# Altium schematic sheet CAN_RS232.SchDoc
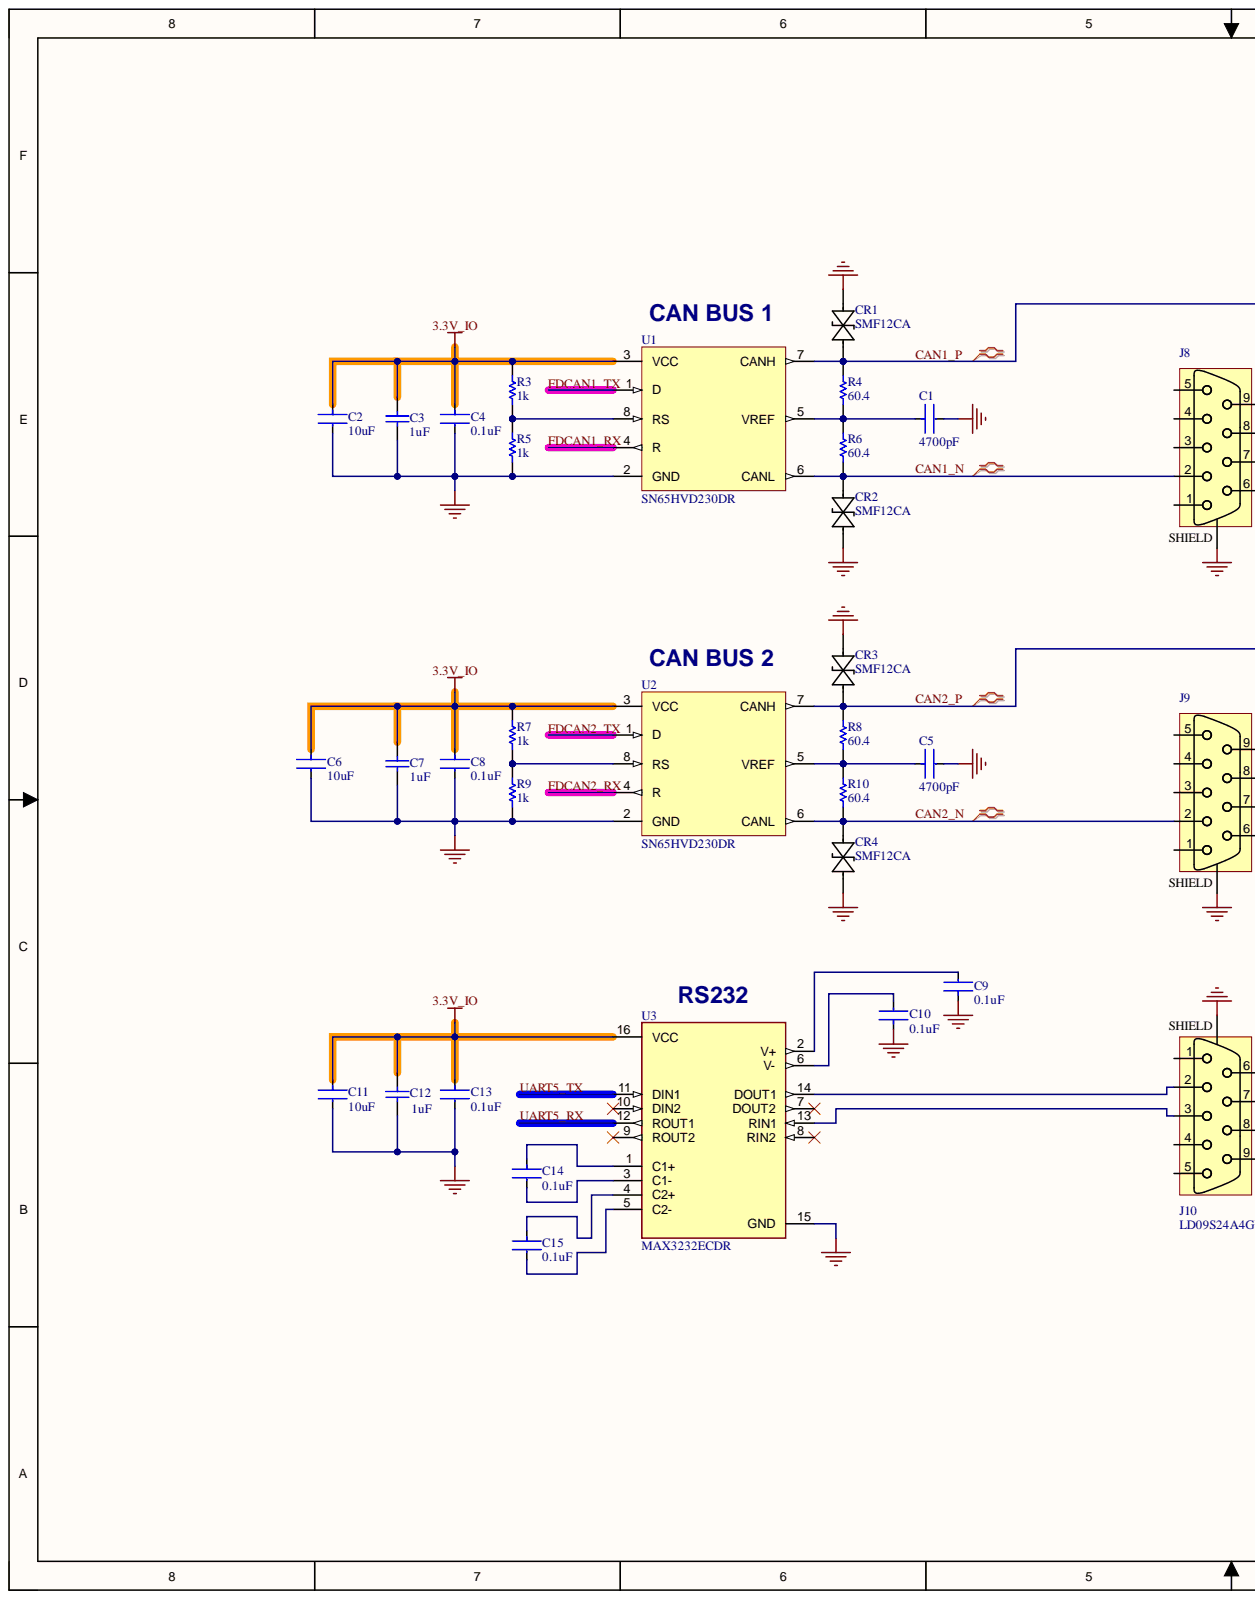
[diagram p0: CAN_RS232.SchDoc, left]
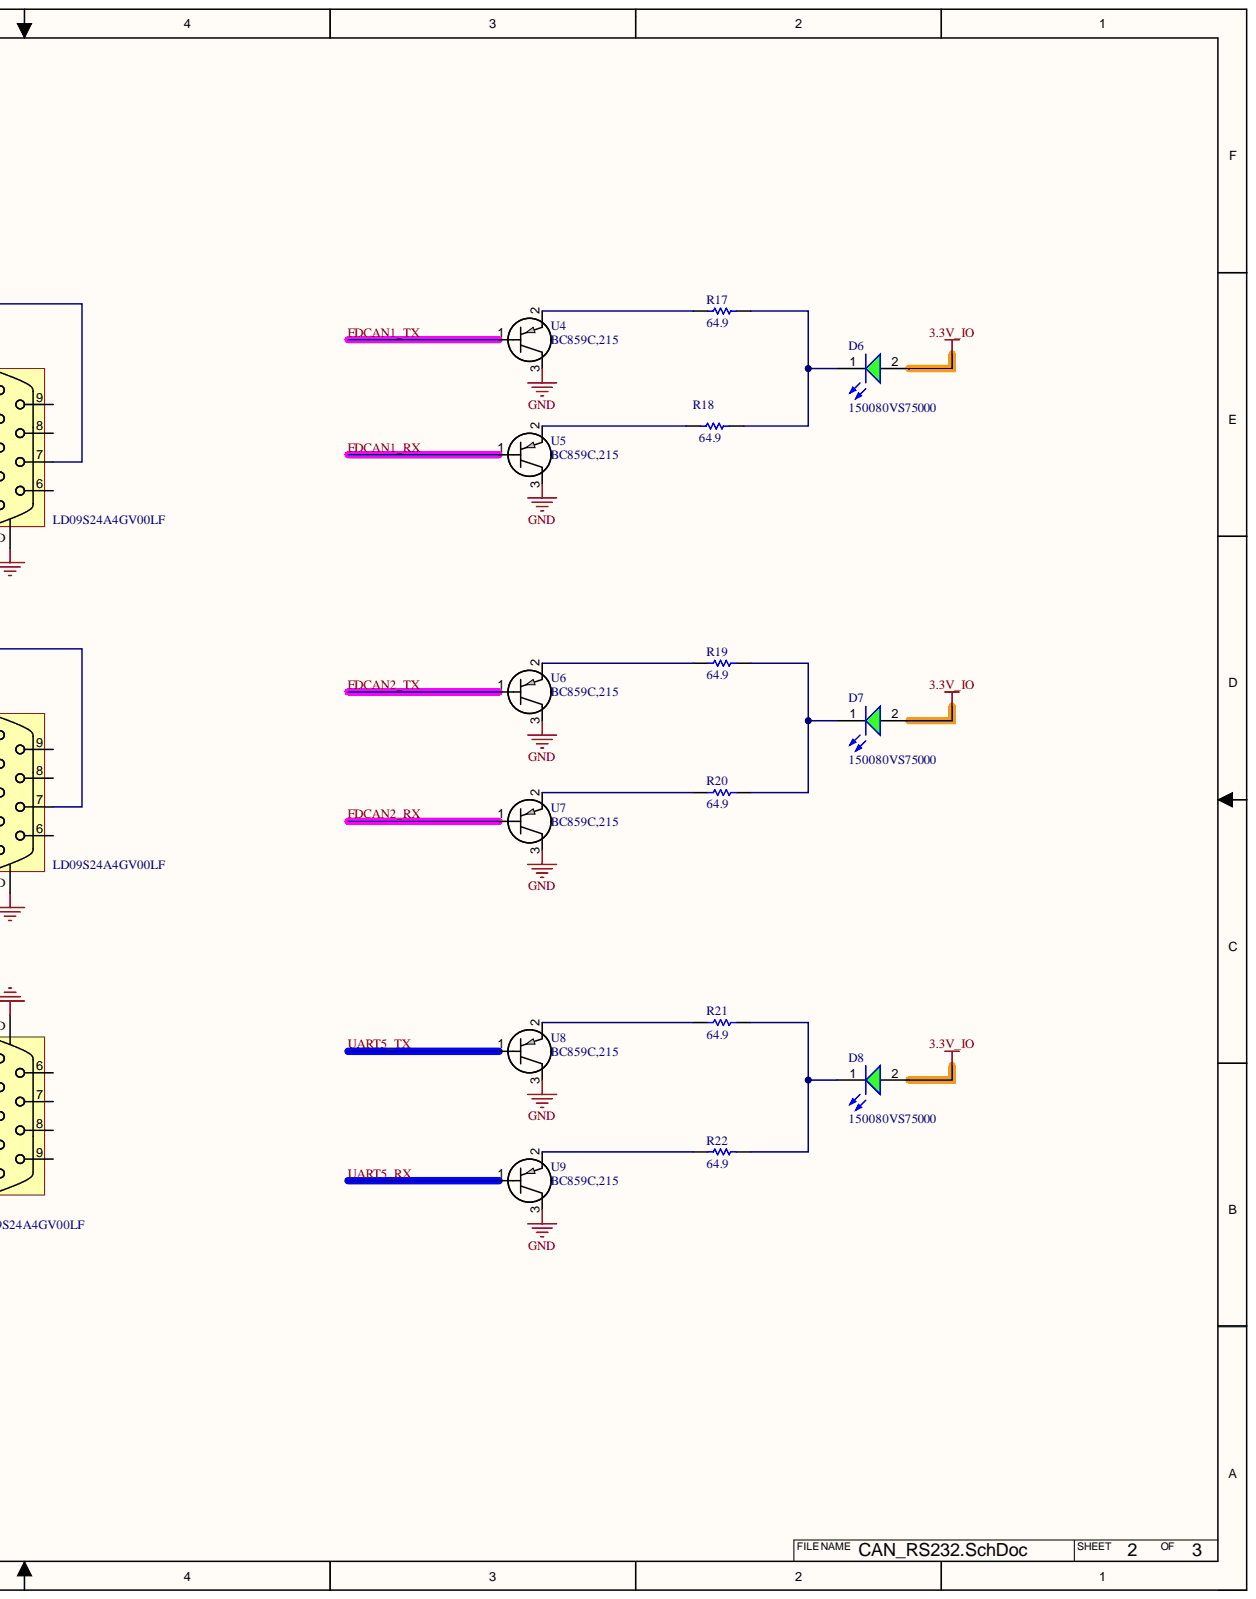
[diagram p1: CAN_RS232.SchDoc, right]

## Parts
C1  4700pF  [FP-CC0805-0_85-MFG]
C2  10uF  [FP-CL31-IPC_B]
C3  1uF  [CAPC2013X100X50ML20T25]
C4  0.1uF  [FP-CC0805-0_85-MFG]
C5  4700pF  [FP-CC0805-0_85-MFG]
C6  10uF  [FP-CL31-IPC_B]
C7  1uF  [CAPC2013X100X50ML20T25]
C8  0.1uF  [FP-CC0805-0_85-MFG]
C9  0.1uF  [FP-CC0805-0_85-MFG]
C10  0.1uF  [FP-CC0805-0_85-MFG]
C11  10uF  [FP-CL31-IPC_B]
C12  1uF  [CAPC2013X100X50ML20T25]
C13  0.1uF  [FP-CC0805-0_85-MFG]
C14  0.1uF  [FP-CC0805-0_85-MFG]
C15  0.1uF  [FP-CC0805-0_85-MFG]
CR1  SMF12CA  [SOD-123F_LTF]
CR2  SMF12CA  [SOD-123F_LTF]
CR3  SMF12CA  [SOD-123F_LTF]
CR4  SMF12CA  [SOD-123F_LTF]
D6  150080VS75000  [WL-SMCW_0805_150080xx75000]
D7  150080VS75000  [WL-SMCW_0805_150080xx75000]
D8  150080VS75000  [WL-SMCW_0805_150080xx75000]
J8  LD09S24A4GV00LF  [ASSMANN_A-DF09A/KG-T2S]
J9  LD09S24A4GV00LF  [ASSMANN_A-DF09A/KG-T2S]
J10  LD09S24A4GV00LF  [ASSMANN_A-DF09A/KG-T2S]
R3  1k  [RESC2013X60X35ML10T20]
R4  60.4  [RESC2013X60X35LL10T20]
R5  1k  [RESC2013X60X35ML10T20]
R6  60.4  [RESC2013X60X35LL10T20]
R7  1k  [RESC2013X60X35ML10T20]
R8  60.4  [RESC2013X60X35LL10T20]
R9  1k  [RESC2013X60X35ML10T20]
R10  60.4  [RESC2013X60X35LL10T20]
R17  64.9  [RESC2013X60X35LL10T20]
R18  64.9  [RESC2013X60X35LL10T20]
R19  64.9  [RESC2013X60X35LL10T20]
R20  64.9  [RESC2013X60X35LL10T20]
R21  64.9  [RESC2013X60X35LL10T20]
R22  64.9  [RESC2013X60X35LL10T20]
U1  SN65HVD230DR  [SOIC127P599X175-8N]
U2  SN65HVD230DR  [SOIC127P599X175-8N]
U3  MAX3232ECDR  [SOIC127P600X175-16N]
U4  BC859C,215  [SOT23_NEX]
U5  BC859C,215  [SOT23_NEX]
U6  BC859C,215  [SOT23_NEX]
U7  BC859C,215  [SOT23_NEX]
U8  BC859C,215  [SOT23_NEX]
U9  BC859C,215  [SOT23_NEX]

## Nets
3.3V_IO: C2.1 C3.2 C4.1 C6.1 C7.2 C8.1 C11.1 C12.2 C13.1 D6.2 D7.2 D8.2 R3.2 R7.2 U1.3(VCC) U2.3(VCC) U3.16(VCC)
GND: C1.2 C2.2 C3.1 C4.2 C5.2 C6.2 C7.1 C8.2 C9.1 C10.1 C11.2 C12.1 C13.2 CR1.1 CR2.1 CR3.2 CR4.2 J8.SHIELD J9.SHIELD J10.SHIELD R5.1 R9.1 U1.2(GND) U2.2(GND) U3.15(GND) U4.3 U5.3 U6.3 U7.3 U8.3 U9.3
CAN1_N: CR2.2 J8.2 R6.1 U1.6(CANL)
CAN1_P: CR1.2 J8.7 R4.2 U1.7(CANH)
CAN2_N: CR4.1 J9.2 R10.1 U2.6(CANL)
CAN2_P: CR3.1 J9.7 R8.2 U2.7(CANH)
FDCAN1_RX: U1.4(R) U5.1
FDCAN1_TX: U1.1(D) U4.1
FDCAN2_RX: U2.4(R) U7.1
FDCAN2_TX: U2.1(D) U6.1
UART5_RX: U3.12(ROUT1) U9.1
UART5_TX: U3.11(DIN1) U8.1
NetC1_1: C1.1 R4.1 R6.2 U1.5(VREF)
NetC5_1: C5.1 R8.1 R10.2 U2.5(VREF)
NetC9_2: C9.2 U3.2(V+)
NetC10_2: C10.2 U3.6(V-)
NetC14_1: C14.1 U3.1(C1+)
NetC14_2: C14.2 U3.3(C1-)
NetC15_1: C15.1 U3.4(C2+)
NetC15_2: C15.2 U3.5(C2-)
NetD6_1: D6.1 R17.1 R18.1
NetD7_1: D7.1 R19.1 R20.1
NetD8_1: D8.1 R21.1 R22.1
NetJ10_2: J10.2 U3.14(DOUT1)
NetJ10_3: J10.3 U3.13(RIN1)
NetR3_1: R3.1 R5.2 U1.8(RS)
NetR7_1: R7.1 R9.2 U2.8(RS)
NetR17_2: R17.2 U4.2
NetR18_2: R18.2 U5.2
NetR19_2: R19.2 U6.2
NetR20_2: R20.2 U7.2
NetR21_2: R21.2 U8.2
NetR22_2: R22.2 U9.2

Not connected: J8 1 3 4 5 6 8 9; J9 1 3 4 5 6 8 9; J10 1 4 5 6 7 8 9; U3 7(DOUT2) 8(RIN2) 9(ROUT2) 10(DIN2)

## Library data of the parts
C1 C5 = CMP-1036-03591-2: CAP CER 4700PF 50V X7R 0805; Manufacturer: Yageo; Manufacturer Part Number: CC0805KRX7R9BB472; Capacitance: 4.7 nF; Material: Ceramic; Tolerance: 0.1; Value: 4.7 nF; Automotive: No; Category: Cap; Component Kind: Standard; ComponentLink2Description: Datasheet; ComponentLink2URL: http://www.yageo.com/documents/recent/UPY-GPHC_Y5V_6.3V-to-50V_8.pdf; Device Class L1: Passive Components; Device Class L2: Capacitors; Device Class L3: Ceramic Capacitors; Digikey Description: CAP CER 4700PF 50V X7R 0805; Footprint Url: http://www.yageo.com/exep/pages/download/literatures/UPY-C_GEN_24.pdf; Height: 0.95mm; Ipc Land Pattern Name: CAPC200125X85; Lead Free: Yes; Library Name: Altium Content Vault; Mouser Description: Multilayer Ceramic Capacitors MLCC - SMD/SMT 4.7nF 50V X7R 10%; Octopart: https://octopart.com/cc0805krx7r9bb472-yageo-192986; Package: CC0805-0.85; RoHS: TRUE; Temperature: -30°C to +85°C; Temperature Characteristic: X7R; Temperature Range High: +85°C; Temperature Range Low: -30°C; Voltage Rating: 50 V
C2 C6 C11 = CMP-13271-001434-1: Cap Ceramic 10uF 25V X7R ±10% SMD 1206 +125°C Embossed T/R; Case Code (Imperial): 1206; Case Code (Metric): 3216; Case/Package: 1206; Component Kind: Standard; Contact Plating: Tin; Depth: 1.6mm; Dielectric: X7R; Height: 1.6mm; Length: 0.126inch; Library Name: Altium Content Vault; Max Operating Temperature: 125°C; Min Operating Temperature: -55°C; Mount: Surface Mount; Package Quantity: 1; Packaging: Tape and Reel; Radiation Hardening: No; Thickness: 0.071inch; Tolerance: 10%; Value: 10uF; Voltage Rating: 25V; Width: 0.063inch
C3 = CMP-2007-03676-1: C0805C105K8RACTU; Manufacturer: Kemet; Component Kind: Standard; Library Name: Altium Content Vault; Part Number: C0805C105K8RACTU
C4 C8-C10 C13-C15 = CMP-2100-03606-3: CAP CER 0.1UF 50V X7R 0805; Manufacturer: Yageo; Manufacturer Part Number: CC0805KRX7R9BB104; Capacitance: 100 nF; Material: Ceramic; Tolerance: 0.1; Value: 100 nF; Automotive: No; Category: Cap; Component Kind: Standard; ComponentLink2Description: Datasheet; ComponentLink2URL: http://www.yageo.com/documents/recent/UPY-GPHC_Y5V_6.3V-to-50V_8.pdf; Device Class L1: Passive Components; Device Class L2: Capacitors; Device Class L3: Ceramic Capacitors; Digikey Description: CAP CER 0.1UF 50V X7R 0805; Footprint Url: http://www.yageo.com/exep/pages/download/literatures/UPY-C_GEN_24.pdf; Height: 0.95mm; Ipc Land Pattern Name: CAPC200125X85; Lead Free: Yes; Library Name: Altium Content Vault; Mouser Description: Multilayer Ceramic Capacitors MLCC - SMD/SMT 100nF 50V X7R 10%; Octopart: https://octopart.com/cc0805krx7r9bb104-yageo-192993; Package: CC0805-0.85; RoHS: TRUE; Temperature: -30°C to +85°C; Temperature Characteristic: X7R; Temperature Range High: +85°C; Temperature Range Low: -30°C; Voltage Rating: 50 V
C7 C12 = CMP-2007-03676-1: Manufacturer: Kemet; Part Number: C0805C105K8RACTU; Component Kind: Standard; Library Name: Altium Content Vault
CR1-CR4 = SMF12CA: No Description Available; Mfr_Name: Littelfuse Inc; Component Kind: Standard; Library Name: SMF12CA.SchLib
D6-D8 = CMP-1426-00009-6: WL-SMCW SMT Mono-Color Chip LED Waterclear; Color: Green; Dominant Wavelength: 570nm; Forward Current: 100mA; Forward Voltage: 2V; Lens Style: Clear, Rectangular; Luminous Intensity: 40mcd; Max Junction Temperature (Tj): 95°C; Max Reverse Voltage (DC): 5V; Peak Wavelength: 572nm; Power Dissipation: 72mW; Test Current: 20mA; Viewing Angle: 140°; Case Code (Imperial): 0805; Case Code (Metric): 2012; Case/Package: 0805; Component Kind: Standard; Height: 700µm; Length: 2mm; Library Name: Altium Content Vault; Max Operating Temperature: 85°C; Min Operating Temperature: -40°C; Mount: Surface Mount; Packaging: Tape and Reel; REACH SVHC: Unknown; Reverse Voltage: 5V; RoHS: Compliant; Wavelength: 570nm; Width: 1.25mm
J8-J10 = LD09S24A4GV00LF: No Description Available; Mfr_Name: Amphenol ICC; Component Kind: Standard; Library Name: LD09S24A4GV00LF.SchLib; RefDes: RefDes; Type: D-Sub Connector Assemblies
R3 R5 R7 R9 = CMP-2100-03671-1: Chip Resistor, 1 KOhm, +/- 1%, 125 mW, -55 to 155 degC, 0805 (2012 Metric), RoHS, Tape and Reel; Manufacturer: Yageo; Max Power Dissipation: 125mW; Resistance: 1KOhm; Temperature Coefficient: 100ppm/degreesC; Voltage Rating: 150V; Case Code (Imperial): 0805; Case Code (Metric): 2012; Case/Package: 0805; Component Kind: Standard; Composition: ThickFilm; Datasheet URL: http://www.yageo.com/NewPortal/yageodocoutput?fileName=/pdf/R-Chip/PYu-RC_Group_51_RoHS_L_5.pdf; Datasheet Version: Rev. 5, 10/2016; Depth: 1.25mm; Height: 0.024inch; Lead Free: LeadFree; Length: 0.079inch; Library Name: Altium Content Vault; Manufacturer URL: http://www.yageo.com/; Max Operating Temperature: 155degC; Military Standard: Not; Min Operating Temperature: -55degC; Number of Pins: 2; Number of Terminations: 2; Package Description: 2-Pin Surface Mounted Device, Body 2 x 1.25 mm; Package Quantity: 1; Package Reference: 0805; Packaging: TapeandReel; Power Rating: 125mW; REACH SVHC: Unknown; RoHSCompliant: true; Termination: SMD/SMT; Tolerance: 1%; Voltage Rating (DC): 150V; Width: 0.049inch
R4 R6 R8 R10 = CMP-2001-01223-1: Manufacturer: Yageo; Part Number: RC0805FR-0760R4L; Component Kind: Standard; Library Name: Altium Content Vault
R17-R22 = CMP-2001-01223-1: Manufacturer: Yageo; Part Number: RC0805FR-0764R9L; Component Kind: Standard; Library Name: Altium Content Vault
U1 U2 = SN65HVD230DR: 3.3 V CAN Transceiver with Standby Mode 8-SOIC -40 to 85; MF: Texas Instruments; Arrow_Electronics-Purchase-URL: https://snapeda.com/shop?store=Arrow+Electronics&id=51767; Availability: Good; Component Kind: Standard; DigiKey-Purchase-URL: https://snapeda.com/shop?store=DigiKey&id=51767; Mouser-Purchase-URL: https://snapeda.com/shop?store=Mouser&id=51767; MP: SN65HVD230DR; Package: SOIC-8 Texas Instruments; Price: None; SnapEDA_Link: https://www.snapeda.com/parts/SN65HVD230DR/Texas%20Instruments/view-part/51767/?ref=snap; Texas_Instruments-Purchase-URL: https://snapeda.com/shop?store=Texas+Instruments&id=51767; Library Name: ertc_symbols.SchLib
U3 = MAX3232ECDR: 3-V to 5.5-V multichannel RS-232 line driver and receiver with 15-kV IEC ESD protection 16-SOIC 0 to 70; Availability: Unavailable; Component Kind: Standard; Library Name: MAX3232ECDR.SchLib; MP: MAX3232ECDR; Package: None; Price: None
U4-U9 = BC859C,215: No Description Available; Mfr_Name: Nexperia; Component Kind: Standard; Library Name: BC859C,215.SchLib
